# Altium schematic sheet Analog_power.SchDoc(Analog_power)
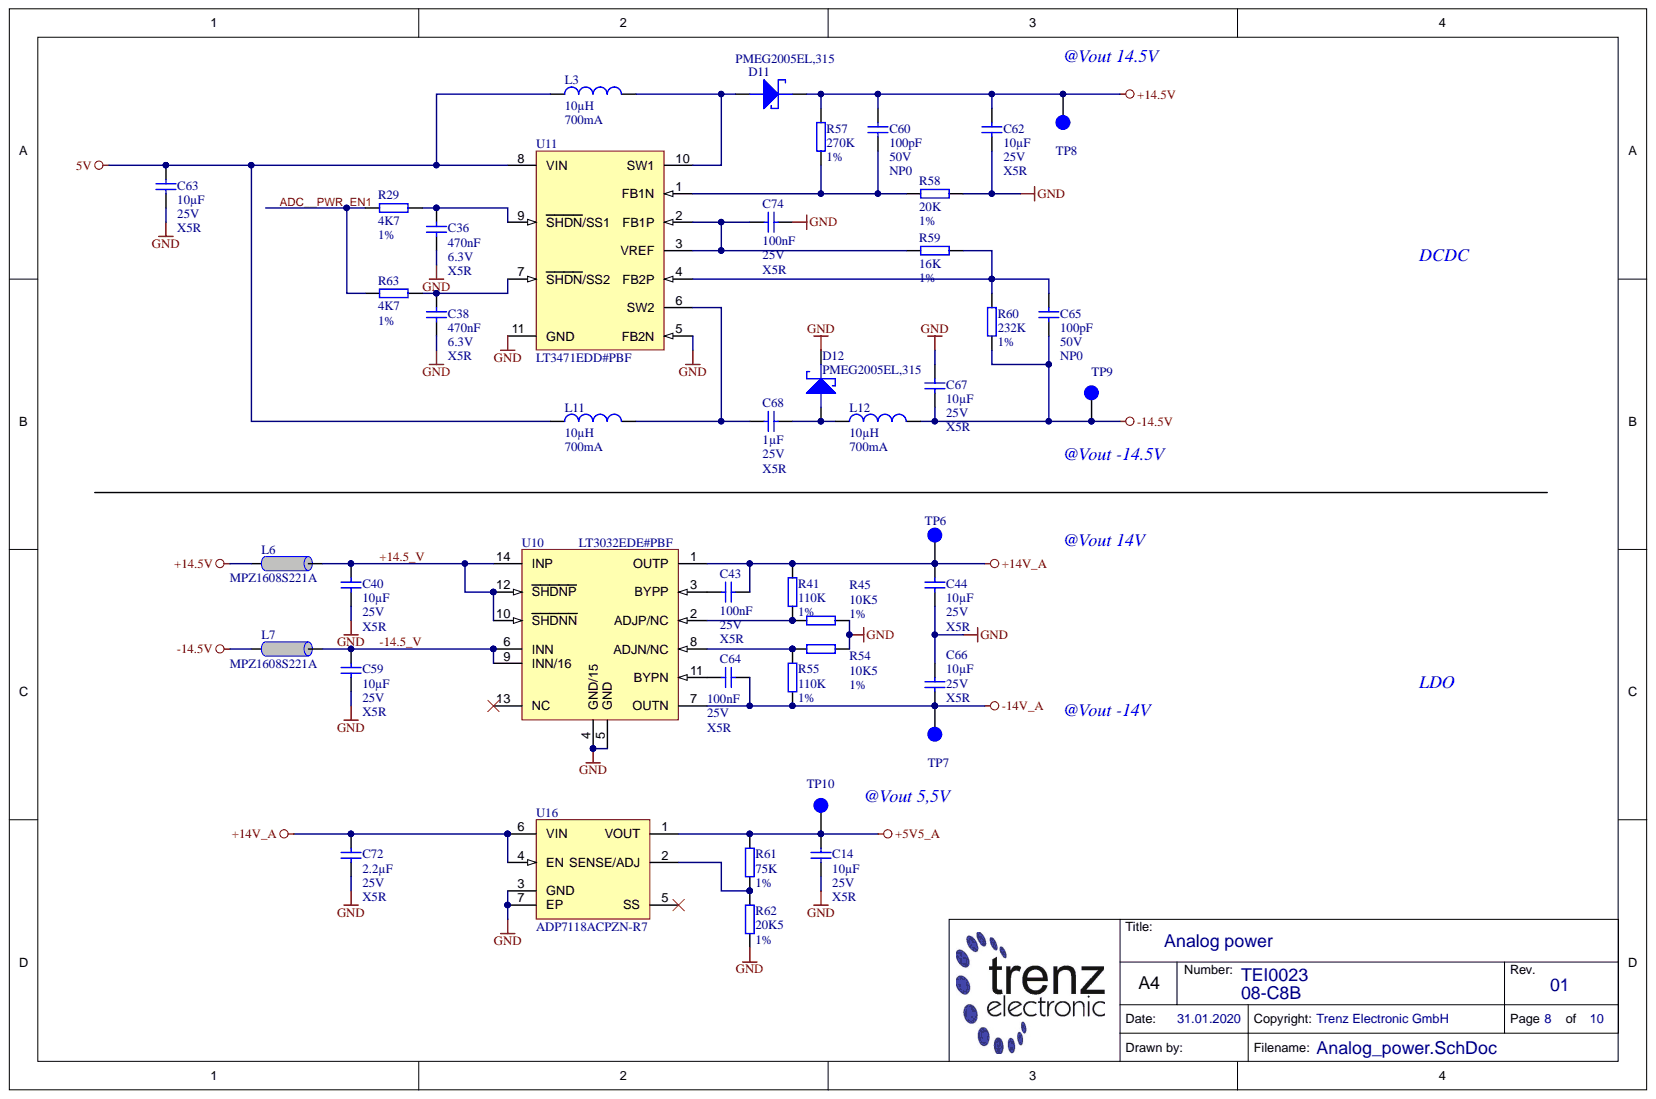
[diagram p0: Analog_power.SchDoc]

## Parts
C14  10µF  Voltage: 25V  Technology: X5R  [CAPC2012X135N]
C36  470nF  Voltage: 6.3V  Technology: X5R  [CAPC0603X33N]
C38  470nF  Voltage: 6.3V  Technology: X5R  [CAPC0603X33N]
C40  10µF  Voltage: 25V  Technology: X5R  [CAPC2012X135N]
C43  100nF  [CAPC1005X55N]
C44  10µF  Voltage: 25V  Technology: X5R  [CAPC2012X135N]
C59  10µF  Voltage: 25V  Technology: X5R  [CAPC2012X135N]
C60  100pF  Voltage: 50V  [CAPC1005X55N]
C62  10µF  Voltage: 25V  Technology: X5R  [CAPC2012X135N]
C63  10µF  Voltage: 25V  Technology: X5R  [CAPC2012X135N]
C64  100nF  Technology: X5R  [CAPC1005X55N]
C65  100pF  Voltage: 50V  [CAPC1005X55N]
C66  10µF  Voltage: 25V  Technology: X5R  [CAPC2012X135N]
C67  10µF  Voltage: 25V  Technology: X5R  [CAPC2012X135N]
C68  1µF  Voltage: 25V  [CAPC1608X90N]
C72  2.2µF  Voltage: 25V  Technology: X5R  [CAPC1608X90N]
C74  100nF  Voltage: 25V  [CAPC1005X55N]
D11  PMEG2005EL,315  [DIODFN98X58X50-2N]
D12  PMEG2005EL,315  [DIODFN98X58X50-2N]
L3  10µH  Voltage: 700mA  [INDC2520X120N]
L6  MPZ1608S221A  [INDC1608X95N]
L7  MPZ1608S221A  [INDC1608X95N]
L11  10µH  Voltage: 700mA  [INDC2520X120N]
L12  10µH  Voltage: 700mA  [INDC2520X120N]
R29  4K7  Tolerance: 1%  [RESC0603X26N]
R41  110K  Tolerance: 1%  [RESC1005X40N]
R45  10K5  Tolerance: 1%  [RESC1005X40N]
R54  10K5  Tolerance: 1%  [RESC1005X40N]
R55  110K  Tolerance: 1%  [RESC1005X40N]
R57  270K  Tolerance: 1%  [RESC1005X40N]
R58  20K  [RESC1005X40N]
R59  16K  Tolerance: 1%  [RESC1005X40N]
R60  232K  Tolerance: 1%  [RESC1005X40N]
R61  75K  Tolerance: 1%  [RESC1005X40N]
R62  20K5  Tolerance: 1%  [RESC1005X40N]
R63  4K7  Tolerance: 1%  [RESC0603X26N]
TP6  Testpoint 0.8mm  [TP_0.8mm]  (value not drawn)
TP7  Testpoint 0.8mm  [TP_0.8mm]  (value not drawn)
TP8  Testpoint 0.8mm  [TP_0.8mm]  (value not drawn)
TP9  Testpoint 0.8mm  [TP_0.8mm]  (value not drawn)
TP10  Testpoint 0.8mm  [TP_0.8mm]  (value not drawn)
U10  LT3032EDE#PBF  [SON50P400X300X80-14N-LT]
U11  LT3471EDD#PBF  [SON50P300X300X80-11T164]
U16  ADP7118ACPZN-R7  [SON65P200X200X60-7T10X16]

## Nets
5V: C63.1 L3.1 L11.1 U11.8(VIN)
+5V5_A: C14.1 R61.2 TP10.1 U16.1(VOUT)
+14.5V: C60.1 C62.1 D11.1 L6.1 R57.1 TP8.1
+14V_A: C43.2 C44.1 C72.1 R41.1 TP6.1 U10.1(OUTP) U16.4(EN) U16.6(VIN)
-14.5V: C65.2 C67.1 L7.1 L12.2 R60.1 TP9.1
-14V_A: C64.2 C66.2 R55.2 TP7.1 U10.7(OUTN)
GND: C14.2 C36.2 C38.2 C40.2 C44.2 C59.2 C62.2 C63.2 C66.1 C67.2 C72.2 C74.2 D12.1 R45.2 R54.2 R58.2 R62.1 U10.4(GND/15) U10.5(GND) U11.5(FB2N) U11.11(GND) U16.3(GND) U16.7(EP)
+14.5_V: C40.1 L6.2 U10.10(SHDNN) U10.12(SHDNP) U10.14(INP)
-14.5_V: C59.1 L7.2 U10.6(INN) U10.9(INN/16)
ADC__PWR_EN1: R29.1 R63.1
NetC36_1: C36.1 R29.2 U11.9(SHDN/SS1)
NetC38_1: C38.1 R63.2 U11.7(SHDN/SS2)
NetC43_1: C43.1 U10.3(BYPP)
NetC60_2: C60.2 R57.2 R58.1 U11.1(FB1N)
NetC64_1: C64.1 U10.11(BYPN)
NetC65_1: C65.1 R59.2 R60.2 U11.4(FB2P)
NetC68_1: C68.1 L11.2 U11.6(SW2)
NetC68_2: C68.2 D12.2 L12.1
NetC74_1: C74.1 R59.1 U11.2(FB1P) U11.3(VREF)
NetD11_2: D11.2 L3.2 U11.10(SW1)
NetR41_2: R41.2 R45.1 U10.2(ADJP/NC)
NetR54_1: R54.1 R55.1 U10.8(ADJN/NC)
NetR61_1: R61.1 R62.2 U16.2(SENSE/ADJ)

Not connected: U10 13(NC); U16 5(SS)

## Library data of the parts
C14 C40 C44 C59 C62 C63 C66 C67 = 25567: KON 10µF 25V X5R 10% SMD BF 2012 (0805); Value: 10u; Temperature-Range: -55/+85; Mixed: SMT; Database Table Name: C_2012_0805; Tolerance: 10%; Library Name: TE.DbLib; Component Kind: Standard; Case: 2012 (0805); AN: 25567
C36 C38 = 24921: KON 470nF 6.3V X5R 20%  SMD BF 0603 (0201); Value: 470n; Temperature-Range: -55/+85; Preferred: Yes; Mixed: SMT; Database Table Name: C_0603_0201; Tolerance: 20%; Library Name: TE.DbLib; Component Kind: Standard; Case: 0603 (0201); AN: 24921
C43 C64 C74 = 22472: KON 100nF 25V X5R ±10% SMD BF 1005 (0402); Value: 100n; Temperature-Range: -55/+85; Preferred: Yes; Mixed: SMT; Database Table Name: C_1005_0402; Library Name: TE.DbLib; Component Kind: Standard; Case: 1005 (0402); AN: 22472
  C43: Voltage: 25V; Technology: X5R
  C64: Voltage: 25V
  C74: Technology: X5R
C60 C65 = 23123: KON 100pF 50V NP0 5% SMD BF 1005 (0402); Value: 100p; Preferred: Yes; Mixed: SMT; Database Table Name: C_1005_0402; Technology: NP0; Library Name: TE.DbLib; Component Kind: Standard; Case: 1005 (0402); AN: 23123
C68 = 26837: KON 1µF 25V X5R ±10% SMD BF 1608 (0603); Value: 1u; Temperature-Range: -55/+85; Preferred: Yes; Mixed: SMT; Database Table Name: C_1608_0603; Tolerance: 10%; Technology: X5R; Library Name: TE.DbLib; Component Kind: Standard; Case: 1608 (0603); AN: 26837
C72 = 27910: KON 2.2uF 25V X5R ±10% SMD BF 1608 (0603); Value: 2.2u; Temperature-Range: -55/+85; Mixed: SMT; Database Table Name: C_1608_0603; Tolerance: ±10%; Library Name: TE.DbLib; Component Kind: Standard; Case: 1608 (0603); AN: 27910
D11 D12 = 29676: Voltage: 20V; Value: 0.5A; Temperature-Range: -65/+150; Mixed: SMT; Database Table Name: DIODE; Technology: Schottky; Storage-Temperature: -65/+150(Abs); Library Name: TE.DbLib; H-ART: PMEG2005EL,315; Description: PMEG2005EL,315; Component Kind: Standard; Case: SOD882 / DFN1006-2; AN: 29676; HelpURL: b:\AD\adlib\datasheet\PMEG2005EL\
L3 L11 L12 = 29717: IND SMD 10µH 20% 700mA 280mOhms 1008 (2520); Value: 10u; Temperature-Range: -40/+125; Mixed: SMT; H-ART: MLP2520S100ST0S1; Database Table Name: L_SMD; Tolerance: 20%; Technology: 280mOhm; Library Name: TE.DbLib; Component Kind: Standard; Case: 1008 (2520); AN: 29717; HelpURL: b:\AD\adlib\datasheet\TDK_MLP2520\
L6 L7 = 22718: Ferritdurchführung MPZ1608S221A; Value: 220 OHm @ 100MHz; Trenz-Stock: Yes; Mixed: SMT; Database Table Name: L_1608_0603; Preferred: Yes; Library Name: TE.DbLib; H-ART: MPZ1608S221A; Component Kind: Standard; Case: 1608 (0603); AN: 22718; HelpURL: B:\AD\adlib\datasheet\MPZ1608
R29 R63 = 25242: WID SMD 4K7 1% 0,05W  BF 0603 (0201); Value: 4.7K; Preferred: Yes; Mixed: SMT; H-ART: RC0201FR-074K7L; Database Table Name: R_0603_0201; Technology: TK100; Library Name: TE.DbLib; Component Kind: Standard; Case: 0603 (0201); AN: 25242; HelpURL: B:\AD\adlib\datasheet\R0201
R41 R55 = 27109: WID SMD 110K 1% 0,063W BF 1005 (0402); Mixed: SMT; Database Table Name: R_1005_0402; Value: 110K; Technology: TK100; Library Name: TE.DbLib; Component Kind: Standard; Case: 1005 (0402); AN: 27109
R45 R54 = 30130: WID SMD 10K5 1% 0,063W  BF 1005 (0402); Voltage: 0.063W; Value: 10.5K; Mixed: SMT; Database Table Name: R_1005_0402; Technology: TK100; Library Name: TE.DbLib; Component Kind: Standard; Case: 1005 (0402); AN: 30130
R57 = 23421: WID SMD 270K 1% 0,063W; Preferred: Yes; Mixed: SMT; Database Table Name: R_1005_0402; Value: 270K; Technology: TK100; Library Name: TE.DbLib; Component Kind: Standard; Case: 1005 (0402); AN: 23421
R58 = 26460: WID SMD 20K 1% 0,063W BF 1005 (0402); Preferred: Yes; Mixed: SMT; Database Table Name: R_1005_0402; Value: 20K; Tolerance: 1%; Technology: TK100; Library Name: TE.DbLib; Component Kind: Standard; Case: 1005 (0402); AN: 26460
R59 = 22756: WID SMD 16K 1% 0,063W BF 1005 (0402); Mixed: SMT; Database Table Name: R_1005_0402; Value: 16K; Technology: TK100; Library Name: TE.DbLib; Component Kind: Standard; Case: 1005 (0402); AN: 22756
R60 = 29582: WID SMD 232K 1% 0,063W BF 1005 (0402); Voltage: 0.063W; Mixed: SMT; Database Table Name: R_1005_0402; Value: 232K; Technology: TK100; Library Name: TE.DbLib; Component Kind: Standard; Case: 1005 (0402); AN: 29582
R61 = 25954: WID SMD 75K 1% 0,063W BF 1005 (0402); Preferred: Yes; Mixed: SMT; Database Table Name: R_1005_0402; Value: 75K; Technology: TK100; Library Name: TE.DbLib; Component Kind: Standard; Case: 1005 (0402); AN: 25954
R62 = 22745: WID SMD 20K5 1% 0,063W BF 1005 (0402); Value: 20.5K; Preferred: Yes; Mixed: SMT; Database Table Name: R_1005_0402; Technology: TK100; Library Name: TE.DbLib; Component Kind: Standard; Case: 1005 (0402); AN: 22745
TP6-TP10 = 26289: Mixed: MECH; Database Table Name: PCB; Library Name: TE.DbLib; Description: Testpoint 0.8mm; Component Kind: Standard; AN: 26289
U10 = 24666: Trenz-Stock: Yes; Mixed: SMT; Database Table Name: LREG; Library Name: TE.DbLib; H-ART: LT3032EDE#PBF; Description: LT3032EDE#PBF; Component Kind: Standard; Case: DFN14LT; AN: 24666; HelpURL: B:\AD\adlib\datasheet\LT3032
U11 = 29486: Trenz-Stock: Yes; Temperature-Range: -40/+85; Mixed: SMT; Database Table Name: DCDC; Storage-Temperature: -65/+125(Abs); Library Name: TE.DbLib; H-ART: LT3471EDD#PBF; Description: LT3471EDD#PBF; Component Kind: Standard; Case: WFDFN10; AN: 29486; HelpURL: b:\AD\adlib\datasheet\LT3471\
U16 = 27881: Mixed: SMT; Database Table Name: LREG; Library Name: TE.DbLib; H-ART: ADP7118ACPZN-R7; Description: ADP7118ACPZN-R7; Component Kind: Standard; Case: DFN8; AN: 27881; HelpURL: b:\AD\adlib\datasheet\ADP7118\
